# Altium schematic sheet Sheet1.SchDoc
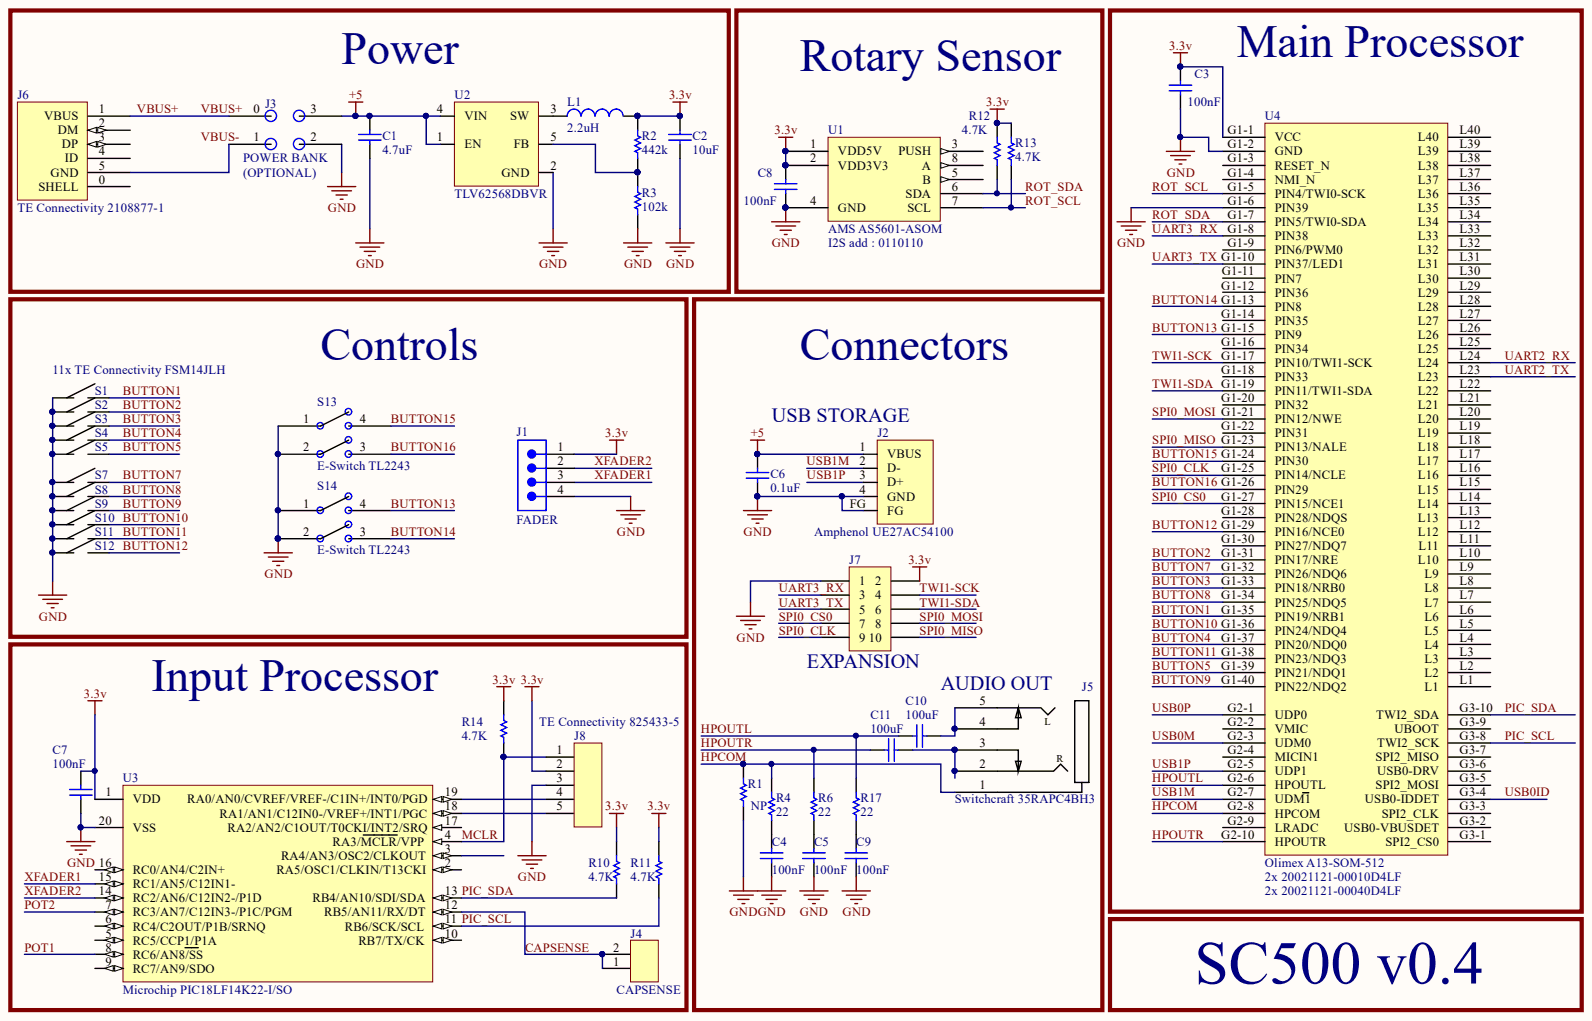
[diagram p0: Sheet1.SchDoc]

## Parts
C1  4.7uF  [CAPC3216X88X50NL20T25]
C2  10uF  [CAPC3216X180X50NL20T25]
C3  100nF  [CAPC3216X88X50NL20T25]
C4  100nF  [CAPC3216X88X50NL20T25]
C5  100nF  [CAPC3216X88X50NL20T25]
C6  0.1uF  [CAPC3216X110X50NL20T25]
C7  100nF  [CAPC3216X88X50NL20T25]
C8  100nF  [CAPC3216X88X50NL20T25]
C9  100nF  [CAPC3216X88X50NL20T25]
C10  100uF  [CAPC3225X270X30NL30T]
C11  100uF  [CAPC3225X270X30NL30T]
J1  FADER  [PCB-4501639-1]
J2  Amphenol UE27AC54100  Manufacturer Part Number: UE27AC54100  [PCB-qkuaejixs6jwml5ll19d-1]
J3  POWER BANK  [PCB-640863-1]
J4  CAPSENSE  [HDR102]
J5  Switchcraft 35RAPC4BH3  [PCB-i49478ydpijisqw62jjz-1]
J6  TE Connectivity 2108877-1  [PCB-swvmklzeh1x4bfefwydg-1]
J7  samtec TSW-105-07-G-D  [PCB-2.54]  (value not drawn)
J8  TE Connectivity 825433-5  [PCB-xti7u9j93a3qzo3mq4li-1]
L1  2.2uH  [PCB-fuzgio0l72uhvfuauccx-1]
R1  NP  [RESC3216X60X45NL10T20]
R2  442k  [RESC3116X65X40NL10T20]
R3  102k  [RESC3116X65X40NL10T20]
R4  22  [RESC3216X60X45NL10T20]
R6  22  [RESC3216X60X45NL10T20]
R10  4.7K  [RESC3116X65X40NL10T20]
R11  4.7K  [RESC3116X65X40NL10T20]
R12  4.7K  [RESC3116X65X40NL10T20]
R13  4.7K  [RESC3116X65X40NL10T20]
R14  4.7K  [RESC3116X65X40NL10T20]
R17  22  [RESC3216X60X45NL10T20]
S1  TE Connectivity / Alcoswitch FSM14JLHX  [PCB-ausgj64kr1kti9bzww7y-1]
S2  TE Connectivity / Alcoswitch FSM14JLHX  [PCB-ausgj64kr1kti9bzww7y-1]
S3  TE Connectivity / Alcoswitch FSM14JLHX  [PCB-ausgj64kr1kti9bzww7y-1]  (value not drawn)
S4  TE Connectivity / Alcoswitch FSM14JLHX  [PCB-ausgj64kr1kti9bzww7y-1]  (value not drawn)
S5  TE Connectivity / Alcoswitch FSM14JLHX  [PCB-ausgj64kr1kti9bzww7y-1]  (value not drawn)
S7  TE Connectivity / Alcoswitch FSM14JLHX  [PCB-ausgj64kr1kti9bzww7y-1]  (value not drawn)
S8  TE Connectivity / Alcoswitch FSM14JLHX  [PCB-ausgj64kr1kti9bzww7y-1]  (value not drawn)
S9  TE Connectivity / Alcoswitch FSM14JLHX  [PCB-ausgj64kr1kti9bzww7y-1]  (value not drawn)
S10  TE Connectivity / Alcoswitch FSM14JLHX  [PCB-ausgj64kr1kti9bzww7y-1]  (value not drawn)
S11  TE Connectivity / Alcoswitch FSM14JLHX  [PCB-ausgj64kr1kti9bzww7y-1]  (value not drawn)
S12  TE Connectivity / Alcoswitch FSM14JLHX  [PCB-ausgj64kr1kti9bzww7y-1]  (value not drawn)
S13  E-Switch TL2243  Manufacturer Part Number: TL2243  [PCB-9wmaaupyl5r06yccozck-1]
S14  E-Switch TL2243  Manufacturer Part Number: TL2243  [PCB-9wmaaupyl5r06yccozck-1]
U1  AMS AS5601-ASOM  [PCB-q2hh09f3jnaqliukgvym-1]
U2  TLV62568DBVR  [TLV62568DBVR]
U3  Microchip PIC18LF14K22-I/SO  Manufacturer Part Number: PIC18LF14K22-I/SO  [SOIC-SO20_N]
U4  Olimex A13-SOM-512  [PCB-ys24v96rmxr2imnz69ba-1]

## Nets
3.3V: C2.2 C3.2 C7.2 C8.2 J1.1 J7.2 J8.2 L1.2 R2.2 R10.2 R11.2 R12.2 R13.2 R14.2 U1.1(VDD5V) U1.2(VDD3V3) U3.1(VDD) U4.G1-1(VCC)
+5: C1.2 C6.2 J2.1(VBUS) J3.3 U2.1(EN) U2.4(VIN)
GND: C1.1 C2.1 C3.1 C4.1 C5.1 C6.1 C7.1 C8.1 C9.1 J1.4 J2.4(GND) J2.FG J3.2 J7.1 J8.3 R1.1 R3.1 S1.T1 S2.T1 S3.T1 S4.T1 S5.T1 S7.T1 S8.T1 S9.T1 S10.T1 S11.T1 S12.T1 S13.1 S13.2 S14.1 S14.2 U1.4(GND) U2.2(GND) U3.20(VSS) U4.G1-2(GND) U4.G1-6(PIN39)
BUTTON1: S1.T2 U4.G1-35(PIN19/NRB1)
BUTTON2: S2.T2 U4.G1-31(PIN17/NRE)
BUTTON3: S3.T2 U4.G1-33(PIN18/NRB0)
BUTTON4: S4.T2 U4.G1-37(PIN20/NDQ0)
BUTTON5: S5.T2 U4.G1-39(PIN21/NDQ1)
BUTTON7: S7.T2 U4.G1-32(PIN26/NDQ6)
BUTTON8: S8.T2 U4.G1-34(PIN25/NDQ5)
BUTTON9: S9.T2 U4.G1-40(PIN22/NDQ2)
BUTTON10: S10.T2 U4.G1-36(PIN24/NDQ4)
BUTTON11: S11.T2 U4.G1-38(PIN23/NDQ3)
BUTTON12: S12.T2 U4.G1-29(PIN16/NCE0)
BUTTON13: S14.4 U4.G1-15(PIN9)
BUTTON14: S14.3 U4.G1-13(PIN8)
BUTTON15: S13.4 U4.G1-24(PIN30)
BUTTON16: S13.3 U4.G1-26(PIN29)
CAPSENSE: J4.1 J4.2 U3.12(RB5/AN11/RX/DT)
HPCOM: J5.1 R1.2 R4.2 U4.G2-8(HPCOM)
HPOUTL: C10.1 R17.2 U4.G2-6(HPOUTL)
HPOUTR: C11.1 R6.2 U4.G2-10(HPOUTR)
MCLR: J8.1 R14.1 U3.4(RA3/MCLR/VPP)
PIC_SCL: R11.1 U3.11(RB6/SCK/SCL) U4.G3-8(TWI2_SCK)
PIC_SDA: R10.1 U3.13(RB4/AN10/SDI/SDA) U4.G3-10(TWI2_SDA)
POT1: U3.8(RC6/AN8/SS)
POT2: U3.7(RC3/AN7/C12IN3-/P1C/PGM)
ROT_SCL: R13.1 U1.7(SCL) U4.G1-5(PIN4/TWI0-SCK)
ROT_SDA: R12.1 U1.6(SDA) U4.G1-7(PIN5/TWI0-SDA)
SPI0_CLK: J7.9 U4.G1-25(PIN14/NCLE)
SPI0_CS0: J7.7 U4.G1-27(PIN15/NCE1)
SPI0_MISO: J7.10 U4.G1-23(PIN13/NALE)
SPI0_MOSI: J7.8 U4.G1-21(PIN12/NWE)
TWI1-SCK: J7.4 U4.G1-17(PIN10/TWI1-SCK)
TWI1-SDA: J7.6 U4.G1-19(PIN11/TWI1-SDA)
UART2_RX: U4.L24
UART2_TX: U4.L23
UART3_RX: J7.3 U4.G1-8(PIN38)
UART3_TX: J7.5 U4.G1-10(PIN37/LED1)
USB0ID: U4.G3-4(USB0-IDDET)
USB0M: U4.G2-3(UDM0)
USB0P: U4.G2-1(UDP0)
USB1M: J2.2(D-) U4.G2-7(UDM1)
USB1P: J2.3(D+) U4.G2-5(UDP1)
VBUS+: J3.0 J6.1(VBUS)
XFADER1: J1.3 U3.15(RC1/AN5/C12IN1-)
XFADER2: J1.2 U3.14(RC2/AN6/C12IN2-/P1D)
NetC4_2: C4.2 R4.1
NetC5_2: C5.2 R6.1
NetC9_2: C9.2 R17.1
NetC10_2: C10.2 J5.4 J5.5
NetC11_2: C11.2 J5.2 J5.3
NetJ3_1: J3.1 J6.5(GND)
NetJ8_4: J8.4 U3.19(RA0/AN0/CVREF/VREF-/C1IN+/INT0/PGD)
NetJ8_5: J8.5 U3.18(RA1/AN1/C12IN0-/VREF+/INT1/PGC)
NetL1_1: L1.1 U2.3(SW)
NetR2_1: R2.1 R3.2 U2.5(FB)
VBUS-:

Not connected: J6 0(SHELL) 2(DM) 3(DP) 4(ID); U1 3(PUSH) 5(B) 8(A); U3 2(RA5/OSC1/CLKIN/T13CKI) 3(RA4/AN3/OSC2/CLKOUT) 5(RC5/CCP1/P1A) 6(RC4/C2OUT/P1B/SRNQ) 9(RC7/AN9/SDO) 10(RB7/TX/CK) 16(RC0/AN4/C2IN+) 17(RA2/AN2/C1OUT/T0CKI/INT2/SRQ); U4 G1-3(RESET_N) G1-4(NMI_N) G1-9(PIN6/PWM0) G1-11(PIN7) G1-12(PIN36) G1-14(PIN35) G1-16(PIN34) G1-18(PIN33) G1-20(PIN32) G1-22(PIN31) G1-28(PIN28/NDQS) G1-30(PIN27/NDQ7) G2-2(VMIC) G2-4(MICIN1) G2-9(LRADC) G3-1(SPI2_CS0) G3-2(USB0-VBUSDET) G3-3(SPI2_CLK) G3-5(SPI2_MOSI) G3-6(USB0-DRV) G3-7(SPI2_MISO) G3-9(UBOOT) L1 L2 L3 L4 L5 L6 L7 L8 L9 L10 L11 L12 L13 L14 L15 L16 L17 L18 L19 L20 L21 L22 L25 L26 L27 L28 L29 L30 L31 L32 L33 L34 L35 L36 L37 L38 L39 L40

## Library data of the parts
C1 = CMP-2511528-2: Capacitor 1206 4.7uF 16V; Manufacturer Part Number: C1206C475Z4VACTU; Capacitance: 4.7e-06 F; Dielectric Characteristic: Y5V; Dielectric Material: Ceramic; Lead-Free Status: Lead Free; Voltage Rating (DC): 16.0 V; Case/Package: 1206; Component Kind: Standard; Library Name: CommunityVault; Mounting Style: Surface Mount; OctopartID: f75e3e6f6bc22899; Octopart Url: https://octopart.com/c1206c475z4vac7800-kemet-3914234; RoHS: Compliant
C2 = CMP-3550774-2: Multilayer Ceramic Capacitors MLCC - SMD/SMT 22uF 10 Volts 20% X7R; Manufacturer Part Number: C1206C226M8RACTU; Capacitance: 2.2e-05 F; Lead-Free Status: R; Voltage Rating (DC): 10.0 V; Capacitance Tolerance: ±20%; Case/Package: 1206; Component Kind: Standard; Dielectric Characteristic: X7R; Dielectric Material: Ceramic; Library Name: CommunityVault; Mounting Style: Surface Mount; OctopartID: 149fdcc2ce7acb58; Octopart Url: https://octopart.com/c1206c226m8ractu-kemet-22544259; Packaging: Cut Tape (CT); REACH SVHC Compliance: No SVHC; RoHS: Compliant
C3-C5 C7-C9 = CMP-123891-2: CAP CER 0.1UF 50V 20% X7R 1206; Manufacturer Part Number: C1206C104M5RACTU; Capacitance: 1e-07 F; Case/Package (SI): 3216; Dielectric Material: Ceramic; Lead-Free Status: R; Voltage Rating (DC): 50.0 V; Capacitance Tolerance: ±20%; Case/Package: 1206; Component Kind: Standard; Dielectric Characteristic: X7R; Library Name: CommunityVault; Mounting Style: Surface Mount; Number of Pins: 2; OctopartID: 2f83e688f3a5f044; Octopart Url: https://octopart.com/c1206c104m5ractu-kemet-129344; Packaging: Cut Tape (CT); RoHS: Compliant; Size-Height: 0.00078 m; Size-Length: 0.0032 m; Size-Width: 0.0016 m
C6 = CMP-2511617-8: 1206 X7R ceramic capacitor, 1uF 16V; Manufacturer Part Number: C1206C105K4RACTU; Capacitance: 1e-06 F; Capacitance Tolerance: ±10%; Lead-Free Status: R; Voltage Rating (DC): 16.0 V; Case/Package: 1206; Component Kind: Standard; Dielectric Characteristic: X7R; Dielectric Material: Ceramic; Library Name: CommunityVault; Mounting Style: Surface Mount; OctopartID: bd2e741f34f862e9; Octopart Url: https://octopart.com/c1206c105k4rac7800-kemet-3910832; RoHS: Compliant
C10 C11 = CMP-498980806d60964e-1: Multilayer Ceramic Capacitor, GRM Series, 100 - F, - 20%, X5R, 6.3 V, 1210 [3225 Metric]; Manufacturer Part Number: GRM32ER60J107ME20L; Case/Package (SI): 3225; Lead-Free Status: Lead Free; Voltage Rating (DC): 6.30 V; Capacitance Tolerance: ±20%; Case/Package: 1210; Component Kind: Standard; Dielectric Characteristic: X5R; Library Name: CommunityVault; Lifecycle Status: Active; Number of Pins: 2; Octopart URL: https://octopart.com/grm32er60j107me20l-murata-197007; Operating Temperature: -55.0 °C to 85.0 °C; Packaging: Tape & Reel (TR), Cut Tape (CT); REACH SVHC Compliance: No SVHC; RoHS: Compliant; Size-Height: 2.50 mm; Size-Length: 3.20 mm; Size-Thickness: 2.50 mm; Size-Width: 2.50 mm
J1 = CMP-4501639-2: CONN HEADER 4POS .100 SGL R/A AU; Manufacturer Part Number: TSW-104-08-L-S-RA; Gender: Male; Lead-Free Status: Lead Free; Number of Contacts: 4; Pin Pitch: 0.00254 m; Component Kind: Standard; Contact Material: Phosphor Bronze; Contact Plating: Gold; Housing Color: Black; Housing Material: Plastic; Library Name: CommunityVault; Mounting Style: Through Hole; Number of Positions: 4; Number of Rows: 1; OctopartID: a7936e9da8f67854; Octopart Url: https://octopart.com/tsw-104-08-l-s-ra-samtec-272899; REACH SVHC Compliance: No SVHC; RoHS: Compliant; Size-Height: 0.00302 m; Size-Length: 0.01016 m; Size-Width: 0.00254 m
J2 = CMP-978f5e3218d24848-1: Conn; Data; USB; Recept; Type A; 4 Pos; PCB Tail; Right Angle; USB Ser.; Gender: Female; Number of Contacts: 4; Component Kind: Standard; Contact Material: Copper Alloy; Library Name: CommunityVault; Octopart URL: http://octopart.com/ue27ac54100-amphenol-12036667; Orientation: Right Angle; RoHS: Compliant
J3 = CMP-640863-5: CONN HEADER VERT 4POS .100 30AU; Manufacturer Part Number: 1-87215-1; ELV Compliant: Compliant; Gender: Male; Lead-Free Status: Lead Free; Mounting Angle: 180.0 ┬░; Number of Contacts: 4; Pin Pitch: 0.00254 m; Component Kind: Standard; Contact Material: Phosphor Bronze; Flammability Rating: UL 94 V-0; Housing Color: Black; Housing Material: Thermoplastic; Library Name: CommunityVault; Lifecycle Status: Active; Mounting Style: PCB; Number of Positions: 4; Number of Rows: 2; OctopartID: 443c8d3580ef9bfb; Octopart Url: http://octopart.com/1-87215-1-te+connectivity+%2F+amp-254389; Orientation: Vertical; Packaging: Bulk; RoHS: Compliant; Size-Length: 0.004674 m
J4 = CMP-4501201-4: CONN HEADER 2POS .100" SGL GOLD; Manufacturer Part Number: TSW-102-07-S-S; Gender: Male; Lead-Free Status: Lead Free; Number of Contacts: 2; Pin Pitch: 0.00254 m; Component Kind: Standard; Contact Material: Phosphor Bronze; Contact Plating: Gold; Housing Color: Black; Housing Material: Plastic; Library Name: CommunityVault; Mounting Style: Through Hole; Number of Positions: 2; Number of Rows: 1; OctopartID: 2036e9382d5dfff1; Octopart Url: https://octopart.com/tsw-102-07-s-s-samtec-272397; RoHS: Compliant; Size-Height: 0.00254 m; Size-Length: 0.00508 m; Size-Width: 0.00254 m
J5 = CMP-329445-15: Conn 3.5MM Stereo Jack F 5 POS Solder RA Thru-Hole 5 Terminal 1 Port; Component Kind: Standard; Library Name: FavoritesLibrary
J6 = CMP-8e0d441f584c51ca-6: Conn Micro USB 2.0 Type B RCP 5 POS Solder RA SMD 5 Terminal 1 Port T/R; Component Kind: Standard; Library Name: CommunityVault
J7 = CMP-fd383436ff4822ff-3: Conn Unshrouded Header HDR 10 POS 2.54mm Solder ST Thru-Hole Automotive Bulk; Lead-Free Status: Lead Free; Number of Contacts: 10; Color: Black; Component Kind: Standard; Contact Material: Phosphor Bronze; Contact Plating: Gold; Housing Color: Black; Housing Material: Plastic; Library Name: CommunityVault; Manufacturer Part Number: TSW-105-07-G-D; Mounting Style: Through Hole; Number of Positions: 10; Number of Rows: 2; OctopartID: fd383436ff4822ff; Octopart URL: http://octopart.com/tsw-105-07-g-d-samtec-272150; Packaging: Bulk; Pin Pitch: 2.54 mm; RoHS: Compliant; Size-Height: 2.54 mm; Size-Length: 12.7 mm; Size-Width: 5.08 mm
J8 = CMP-a17c6af386817ebb-12: Conn Unshrouded Header HDR 5 POS 2.54mm Solder ST Thru-Hole; Component Kind: Standard; Library Name: CommunityVault
L1 = CMP-7545bccc63e2aa82-1: Inductor Power Shielded Wirewound 2.2uH 30% 100KHz Ferrite 3.7A 26mOhm DCR 2424 T/R; Manufacturer Part Number: NR6028T2R2N; Inductance: 2.20 H; Self Resonant Frequency: 68.0 MHz; Component Kind: Standard; Halogen Free Status: Halogen Free; Library Name: CommunityVault; Lifecycle Status: Active; Mounting Style: Surface Mount; Number of Pins: 2; OctopartID: 7545bccc63e2aa82; Packaging: Cut Tape (CT); RoHS: Compliant
R1 R4 R6 R17 = CMP-06e9cd93c4d2d92e-3: Surface Mount Thick Film Resistor, RC Series, 22 ohm, 250 mW, - 1%, 200 V, 1206 [3216 Metric]; Manufacturer Part Number: RC1206FR-0722RL; Case/Package (SI): 3216; Lead-Free Status: Lead Free; Power Rating: 250 mW; Resistance: 22.0 Ω; Voltage Rating (DC): 200 V; Case/Package: 1206; Component Kind: Standard; Library Name: CommunityVault; Mounting Style: Surface Mount; Number of Pins: 2; OctopartID: 06e9cd93c4d2d92e; Octopart URL: http://octopart.com/rc1206fr-0722rl-yageo-40953693; Packaging: Tape & Reel (TR), Cut Tape (CT); REACH SVHC Compliance: No SVHC; Resistance Tolerance: ±1%; RoHS: Compliant; Size-Height: 550 µm; Size-Length: 3.20 mm; Size-Width: 1.60 mm
R2 = CMP-1855773-2: RES SMD 442K OHM 1% 1/4W 1206; Manufacturer Part Number: RC1206FR-07442KL; Case/Package (SI): 3216; Lead-Free Status: Lead Free; Power Rating: 0.25 W; Resistance: 442000.0 Ω; Voltage Rating (DC): 200.0 V; Case/Package: 1206; Component Kind: Standard; Library Name: CommunityVault; Mounting Style: Surface Mount; OctopartID: c4480d51f1ce1b8f; Octopart Url: https://octopart.com/rc1206fr-07442kl-yageo-1249504; Resistance Tolerance: ±1%; RoHS: Compliant; Size-Height: 0.00055 m; Size-Length: 0.0032 m; Size-Width: 0.0016 m
R3 = CMP-1855731-2: RES SMD 102K OHM 1% 1/4W 1206; Manufacturer Part Number: RC1206FR-07102KL; Case/Package (SI): 3216; Lead-Free Status: Lead Free; Power Rating: 0.25 W; Resistance: 102000.0 Ω; Voltage Rating (DC): 200.0 V; Case/Package: 1206; Component Kind: Standard; Library Name: CommunityVault; Mounting Style: Surface Mount; OctopartID: 02facbe32bf1dd3d; Octopart Url: https://octopart.com/rc1206fr-07102kl-yageo-1248365; Resistance Tolerance: ±1%; RoHS: Compliant; Size-Height: 0.00055 m; Size-Length: 0.0032 m; Size-Width: 0.0016 m
R10-R14 = CMP-5390195-2: Thick Film Resistors 4.7K OHM 5%; Manufacturer Part Number: RC1206JR-134K7L; Lead-Free Status: Lead Free; Resistance: 4700.0 Ω; Case/Package: 1206; Component Kind: Standard; Library Name: CommunityVault; Mounting Style: Surface Mount; OctopartID: 3f50f6a201aae097; Octopart Url: https://octopart.com/rc1206jr-134k7l-yageo-16259996; Resistance Tolerance: ±5%; RoHS: Compliant
S1-S5 S7-S12 = CMP-4f4287dffbbc9085-3: FSM14JLHX=6MM Tact Switch, High Temp; Component Kind: Standard; Library Name: CommunityVault
S13 S14 = CMP-599490f5d9408653-1: Switch Tactile N.O. SPST Round Button PC Pins 0.05A 12VDC 1.77N Thru-Hole; Lead-Free Status: Lead Free; Component Kind: Standard; Library Name: CommunityVault; Mounting Style: PC Board; OctopartID: 599490f5d9408653; RoHS: Compliant
U1 = CMP-bfed75fe9787602a-1: RotaryPosition Sensor 5.5V 8-Pin SOIC Tape and Reel; Component Kind: Standard; Library Name: CommunityVault; OctopartID: bfed75fe9787602a
U2 = CMP-1b92d60df203c455-4: 1-A High Efficiency Step-down Buck Converter in SOT23 Package 5-SOT-23 -40 to 125; Component Kind: Standard; Library Name: CommunityVault
U3 = CMP-1155955-2: Flash Microcontroller with nanoWatt XLP Technology, 20-pin SOIC, Industrial Temperature; Clock Speed: 64000000.0 Hz; FLASH Memory Size: 16384 B; Lead-Free Status: Lead Free; RAM Memory Size: 512 B; Component Kind: Standard; Library Name: CommunityVault; Lifecycle Status: Not Listed by Manufacturer; Mounting Style: Surface Mount; Number of Pins: 20; OctopartID: 89af402861a15668; Octopart Url: https://octopart.com/pic18lf14k22-i%2Fso-microchip-9293160; REACH SVHC Compliance: No SVHC; RoHS: Compliant
U4 = CMP-32r4v9r4g2a0b2vspqrc-1: Olimex A13-SOM-512 SoM; Component Kind: Standard; CustomComponentID: 32r4v9r4g2a0b2vspqrc; Library Name: FavoritesLibrary
